# Altium schematic sheet Motherboard_Bracelet_uC.SchDoc(Motherboard_Bracelet_uC)
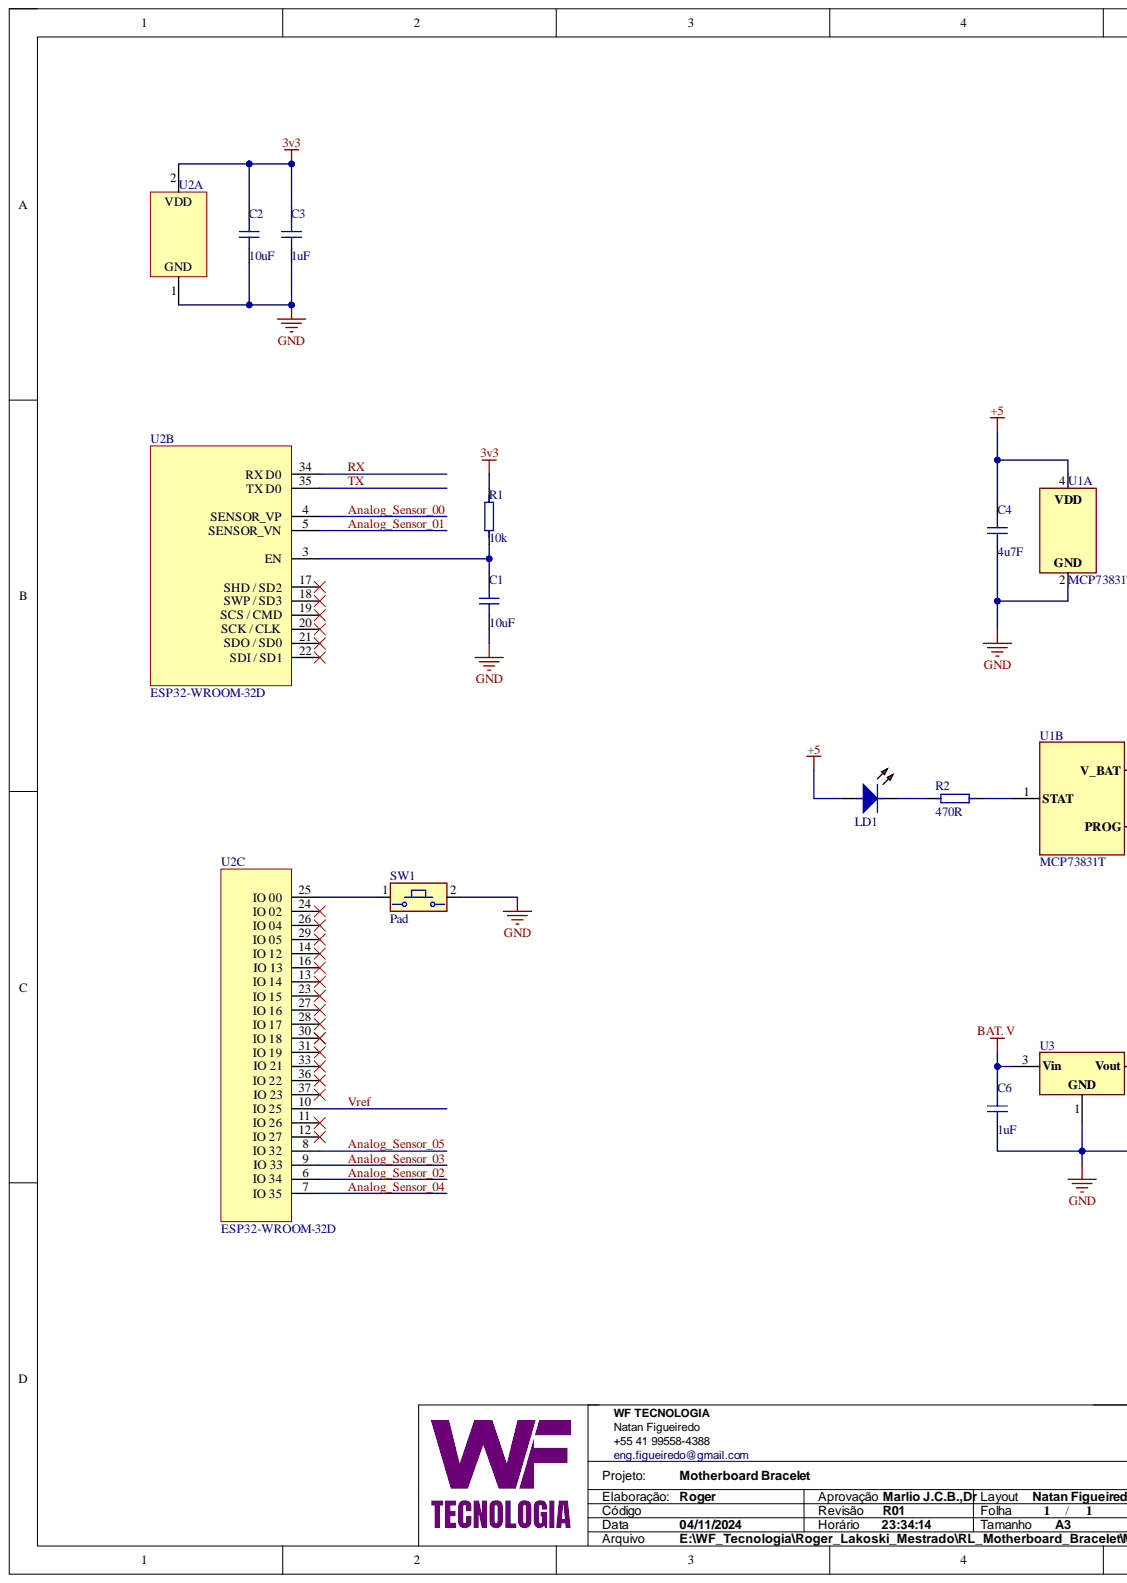
[diagram p0: Motherboard_Bracelet_uC.SchDoc, left]
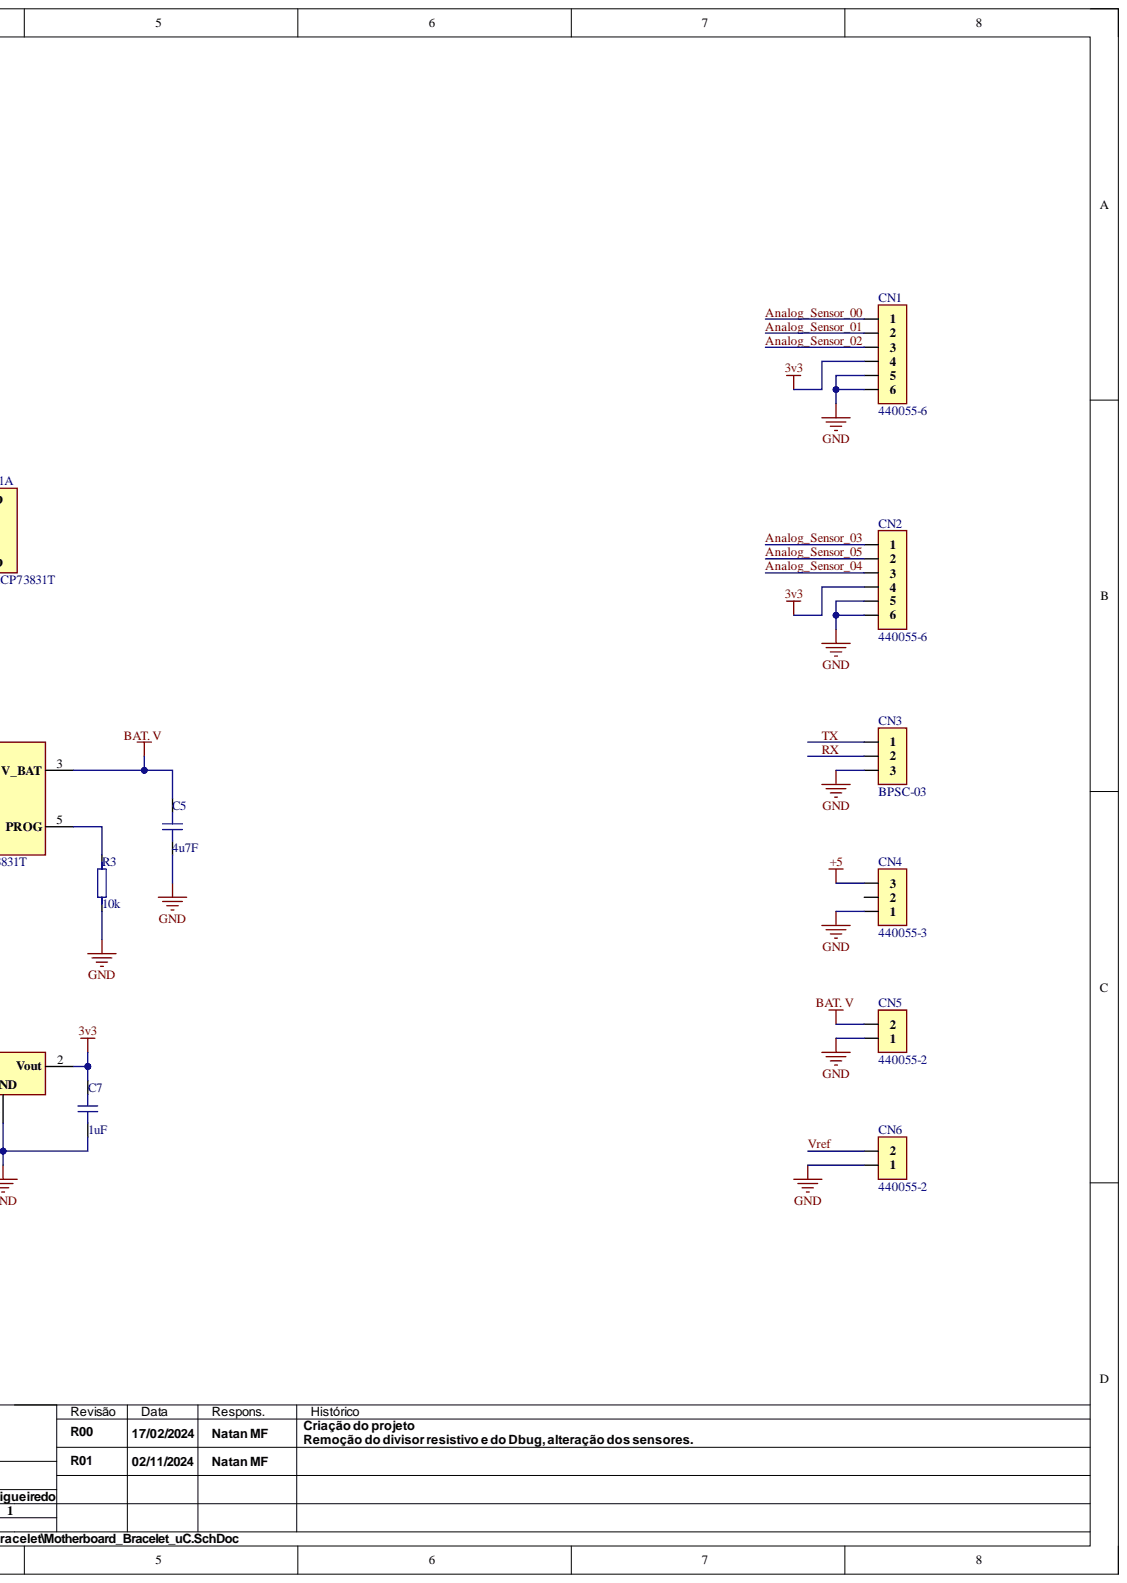
[diagram p1: Motherboard_Bracelet_uC.SchDoc, right]

## Parts
C1  10uF  [SMD_C_0805]
C2  10uF  [SMD_C_0805]
C3  1uF  [SMD_C_0603]
C4  4u7F  [SMD_C_0603]
C5  4u7F  [SMD_C_0603]
C6  1uF  [SMD_C_0603]
C7  1uF  [SMD_C_0603]
CN1  440055-6  [440055-6]
CN2  440055-6  [440055-6]
CN3  BPSC-03  [BPSC-03]
CN4  440055-3  [440055-3]
CN5  440055-2  [440055-2]
CN6  440055-2  [440055-2]
LD1  Red-Diffused  [SMD_L0805]  (value not drawn)
R1  10k  [SMD_R0603_F]
R2  470R  [SMD_R0603_F]
R3  10k  [SMD_R0603_F]
SW1  Pad  [Button_PAD(0805)]
U1  MCP73831T  [SOT-23-5]  (parts A,B)
U2  ESP32-WROOM-32D  [MODULO_ESP32-WROOM-32D]  (parts A,B,C)
U3  MCP1700T  [SOT23-3]  (value not drawn)

## Nets
3v3: C2.1 C3.1 C7.1 CN1.4 CN2.4 R1.2 U2.2 U3.2
+5: C4.1 CN4.3 LD1.1 U1.4
BAT. V: C5.1 C6.1 CN5.2 U1.3(V_BAT) U3.3
GND: C1.2 C2.2 C3.2 C4.2 C5.2 C6.2 C7.2 CN1.5 CN1.6 CN2.5 CN2.6 CN3.3 CN4.1 CN5.1 CN6.1 R3.1 SW1.2 U1.2 U2.1 U3.1
Analog_Sensor_00: CN1.1 U2.4(SENSOR_VP)
Analog_Sensor_01: CN1.2 U2.5(SENSOR_VN)
Analog_Sensor_02: CN1.3 U2.6(IO_34)
Analog_Sensor_03: CN2.1 U2.9(IO_33)
Analog_Sensor_04: CN2.3 U2.7(IO_35)
Analog_Sensor_05: CN2.2 U2.8(IO_32)
RX: CN3.2 U2.34(RX_D0)
TX: CN3.1 U2.35(TX_D0)
Vref: CN6.2 U2.10(IO_25)
NetC1_1: C1.1 R1.1 U2.3(EN)
NetLD1_2: LD1.2 R2.2
NetR2_1: R2.1 U1.1(STAT)
NetR3_2: R3.2 U1.5(PROG)
NetSW1_1: SW1.1 U2.25(IO_00)

Not connected: CN4 2; U2 11(IO_26) 12(IO_27) 13(IO_14) 14(IO_12) 16(IO_13) 17(SHD_/_SD2) 18(SWP_/_SD3) 19(SCS_/_CMD) 20(SCK_/_CLK) 21(SDO_/_SD0) 22(SDI_/_SD1) 23(IO_15) 24(IO_02) 26(IO_04) 27(IO_16) 28(IO_17) 29(IO_05) 30(IO_18) 31(IO_19) 33(IO_21) 36(IO_22) 37(IO_23)

## Library data of the parts
C1 C2 = Cap_Cer._10uF_±10%_10V_X5R_SMD(0805): 10 µF ±10% 10V Ceramic Capacitor X5R 0805 (2012 Metric); Manufacturer: KEMET; Part Number: C0805C106K8PAC7800; CDM: C10686; Type: SMD; Coeficiente de temperatura: X5R; Component Kind: Standard; ComponentLink1Description: Datasheet 1; ComponentLink1URL: https://connect.kemet.com:7667/gateway/IntelliData-ComponentDocumentation/1.0/download/datasheet/C0805C106K8PACTU; Size: 0805; Supplier 1: Digi-Key; Supplier Part Number 1: 399-C0805C106K8PAC7800TR-ND; Value: 10uF; Library Name: Bib_LOTUS.IntLib
C3 C6 C7 = Cap_Cer._1uF_±10%_50V_X5R_SMD(0603): 1 µF ±10% 50V Ceramic Capacitor X5R 0603 (1608 Metric); Manufacturer: TDK Corporation; Part Number: C1608X5R1H105K080AB; CDM: C10682; Type: SMD; Coeficiente de temperatura: X5R; Component Kind: Standard; ComponentLink1Description: Datasheet 1; ComponentLink1URL: https://product.tdk.com/system/files/dam/doc/product/capacitor/ceramic/mlcc/catalog/mlcc_commercial_general_en.pdf; Size: 0603; Supplier 1: Digi-Key; Supplier Part Number 1: 445-7468-2-ND; Value: 1uF; Library Name: Bib_LOTUS.IntLib
C4 C5 = Cap_Cer._4u7F_±10%_25V_X5R_SMD(0603): 4.7 µF ±10% 25V Ceramic Capacitor X5R 0603 (1608 Metric); Manufacturer: Samsung Electro-Mechanics; Part Number: CL10A475KA8NQNC; CDM: C12485; Type: SMD; Coeficiente de temperatura: X5R; Component Kind: Standard; ComponentLink1Description: Datasheet 1; ComponentLink1URL: https://www.digikey.com.br/en/models/3887558; Size: 0603; Supplier 1: Digi-Key; Supplier Part Number 1: 1276-1900-2-ND; Value: 4u7F; Library Name: Bib_LOTUS.IntLib
CN1 CN2 = Conn_6Vias_90°_HEADER_440055-6: Conector barra de pinos Furo passante, ângulo direito 6 position 0,079" (2,00mm)  Conector Femea: 440129-6  Terminal: 440132-1; Manufacturer: TE Connectivity AMP Connectors; System: Connector; Component Kind: Standard; ComponentLink1Description: Datasheet 1; ComponentLink1URL: https://www.te.com/usa-en/product-440055-6.datasheet.pdf; Part Number: 440055-6; Size: 2.00MM; Supplier 1: Digi-Key; Supplier Part Number 1: A100047-ND; Type: PTH; Value: 440055-6; Library Name: Bib_LOTUS.IntLib
CN3 = Conn_3Vias_180°_BP: BARRA DE PINOS FILEIRA SIMPLES 180 GRAUS 03 VIAS DOURADA, PASSO DE 2,54MM, COMPRIMENTO DE 11,54MM; Manufacturer: Metaltex; System: Connector; Component Kind: Standard; ComponentLink1Description: Datasheet 1; ComponentLink1URL: https://www.metaltex.com.br/assets/produtos/pdf/pc2.pdf; Part Number: BPSC-03; Size: Passo 2.54mm; Supplier 1: Metaltex; Supplier Part Number 1: BPSC-03; Type: PTH; Value: BPSC-03; Library Name: Bib_LOTUS.IntLib
CN4 = Conn_3Vias_90°_HEADER_440055-3: Conector barra de pinos Furo passante, ângulo direito 3 position 0,079" (2,00mm)  Conector Femea: 440129-3  Terminal: 440132-1; Manufacturer: TE Connectivity AMP Connectors; System: Connector; Component Kind: Standard; ComponentLink1Description: Datasheet 1; ComponentLink1URL: https://www.te.com/usa-en/product-440055-6.datasheet.pdf; Library Name: Conector.SchLib; Part Number: 440055-3; Size: 2.00MM; Supplier 1: Digi-Key; Supplier Part Number 1: A100044-ND; Type: PTH; Value: 440055-3
CN5 CN6 = Conn_2Vias_90°_HEADER_440055-2: Conector barra de pinos Furo passante, ângulo direito 2 position 0,079" (2,00mm)  Conector Femea: 440129-2  Terminal: 440132-1; Manufacturer: TE Connectivity AMP Connectors; System: Connector; Component Kind: Standard; ComponentLink1Description: Datasheet 1; ComponentLink1URL: https://www.te.com/usa-en/product-440055-2.datasheet.pdf; Library Name: Conector.SchLib; Part Number: 440055-2; Size: 2.00MM; Supplier 1: Digi-Key; Supplier Part Number 1: A100043-ND; Type: PTH; Value: 440055-2
LD1 = LED_Red-Diffused_SMD(0805): Vermelho 633nm Indicadores LED - Discreto 2V 0805 (métrico 2012); Manufacturer: ams-OSRAM USA INC.; Part Number: LS R976-NR-1; CDM: C14983; Type: SMD; Component Kind: Standard; ComponentLink1Description: Datasheet 1; ComponentLink1URL: https://dammedia.osram.info/media/resource/hires/osram-dam-5178068/LS%20R976_EN.pdf; Size: 0805; Supplier 1: Digi-Key; Supplier Part Number 1: 475-LSR976-NR-1TR-ND; Value: Red-Diffused; Library Name: Bib_LOTUS.IntLib
R1 R3 = RES_10k_±1%_0.1W_SMD(0603): 10 kOhms ±1% 0,1W, 1/10W Resistor de chip 0603 (métrico 1608) Resistente à umidade Filme grosso; Manufacturer: YAGEO; Part Number: RC0603FR-0710KL; CDM: C10436; Component Kind: Standard; ComponentLink1Description: Datasheet 1; ComponentLink1URL: https://www.yageo.com/upload/media/product/productsearch/datasheet/rchip/PYu-RC_Group_51_RoHS_L_12.pdf; Size: 0603; Supplier 1: Digi-Key; Supplier Part Number 1: 311-10.0KHRTR-ND; Type: SMD; Value: 10k; Library Name: Bib_LOTUS.IntLib
R2 = RES_470R_±1%_0.1W_SMD(0603): 470 Ohms ±1% 0.1W, 1/10W Chip Resistor 0603 (1608 Metric) Moisture Resistant Thick Film; Manufacturer: YAGEO; Part Number: RC0603FR-07470RL; CDM: C10422; Component Kind: Standard; ComponentLink1Description: DataSheet; ComponentLink1URL: https://www.yageo.com/upload/media/product/productsearch/datasheet/rchip/PYu-RC_Group_51_RoHS_L_12.pdf; Size: 0603; Supplier 1: Digi-Key; Supplier Part Number 1: 311-470HRTR-ND; Type: SMD; Value: 470R; Library Name: Bib_LOTUS.IntLib
SW1 = Button_PAD(0805): Botão em pad   Tamnha 0805; Component Kind: Standard; ComponentLink1Description: DataSheet; Library Name: Button.SchLib; Size: 0805; Supplier 1: Digi-Key; Value: Pad
U1 = Batt_Control_MCP73831T-2ACI/OT: CI carregador Íon / polímero de lítio SOT-23-5; Manufacturer: Microchip Technology; Component Kind: Standard; ComponentLink2Description: Datasheet 1; ComponentLink2URL: https://ww1.microchip.com/downloads/en/DeviceDoc/MCP73831-Family-Data-Sheet-DS20001984H.pdf; Part Number: MCP73831T-2ACI/OT; Size: SOT23-5; Supplier 1: Digi-Key; Supplier Part Number 1: MCP73831T-2ACI/OTTR-ND; Type: SMD; Value: MCP73831T; Library Name: Bib_LOTUS.IntLib
U2 = MODULO_ESP32_WROOM_32D_N4: RF TXRX MODULE BT TRACE ANT SMD  Bluetooth, WiFi 802.11b/g/n, Bluetooth v4.2 + EDR, Classe 1, 2 e 3 Módulo transceptor 2,4GHz a 2,5GHz Integrado, Trace Montagem em superfície; Manufacturer: ESP32; Part Number: ESP32-WROOM-32D-N4; Component Kind: Standard; ComponentLink1Description: Datasheet/Microcontrolador; ComponentLink1URL: https://www.espressif.com/sites/default/files/documentation/esp32-wroom-32d_esp32-wroom-32u_datasheet_en.pdf; Supplier 1: Digi-Key; Supplier Part Number 1: 1965-ESP32-WROOM-32D-N4TR-ND; Type: MODE; Value: ESP32-WROOM-32D; Library Name: Bib_LOTUS.IntLib
U3 = RegulatorsV_MCP1700T-3302E/TT: IC REG LINEAR 3.3V 250MA  CI regulador de tensão linear Positivo Fixo 1 Saída 250mA SOT-23-3; Manufacturer: Microchip Technology; Component Kind: Standard; ComponentLink1Description: DataSheet; ComponentLink1URL: https://ww1.microchip.com/downloads/en/DeviceDoc/MCP1700-Data-Sheet-20001826F.pdf; Part Number: MCP1700T-3302E/TT; Size: SOT23-3; Supplier 1: Digi-Key; Supplier Part Number 1: MCP1700T3302ETTTR-ND; Type: SMD; Value: MCP1700T; Library Name: Bib_LOTUS.IntLib
